# Altium schematic sheet 09 - MICROHEADER - JX3.SchDoc("09 - MICROHEADER - JX3")
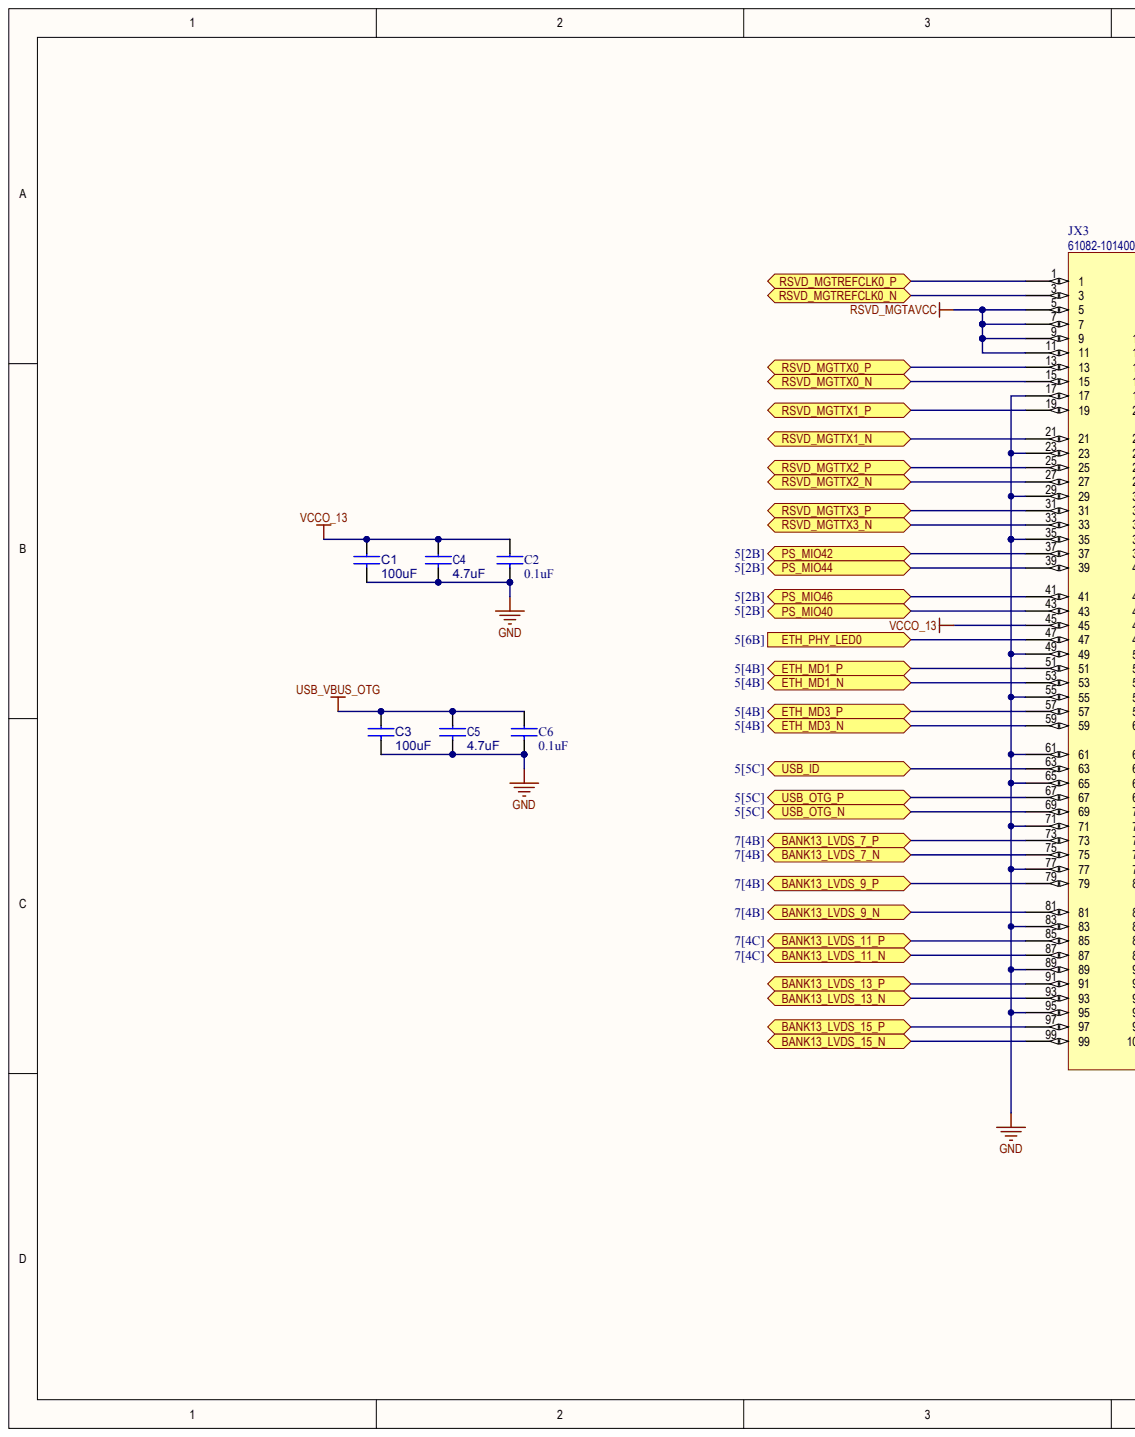
[diagram p0: 09 - MICROHEADER - JX3.SchDoc, left]
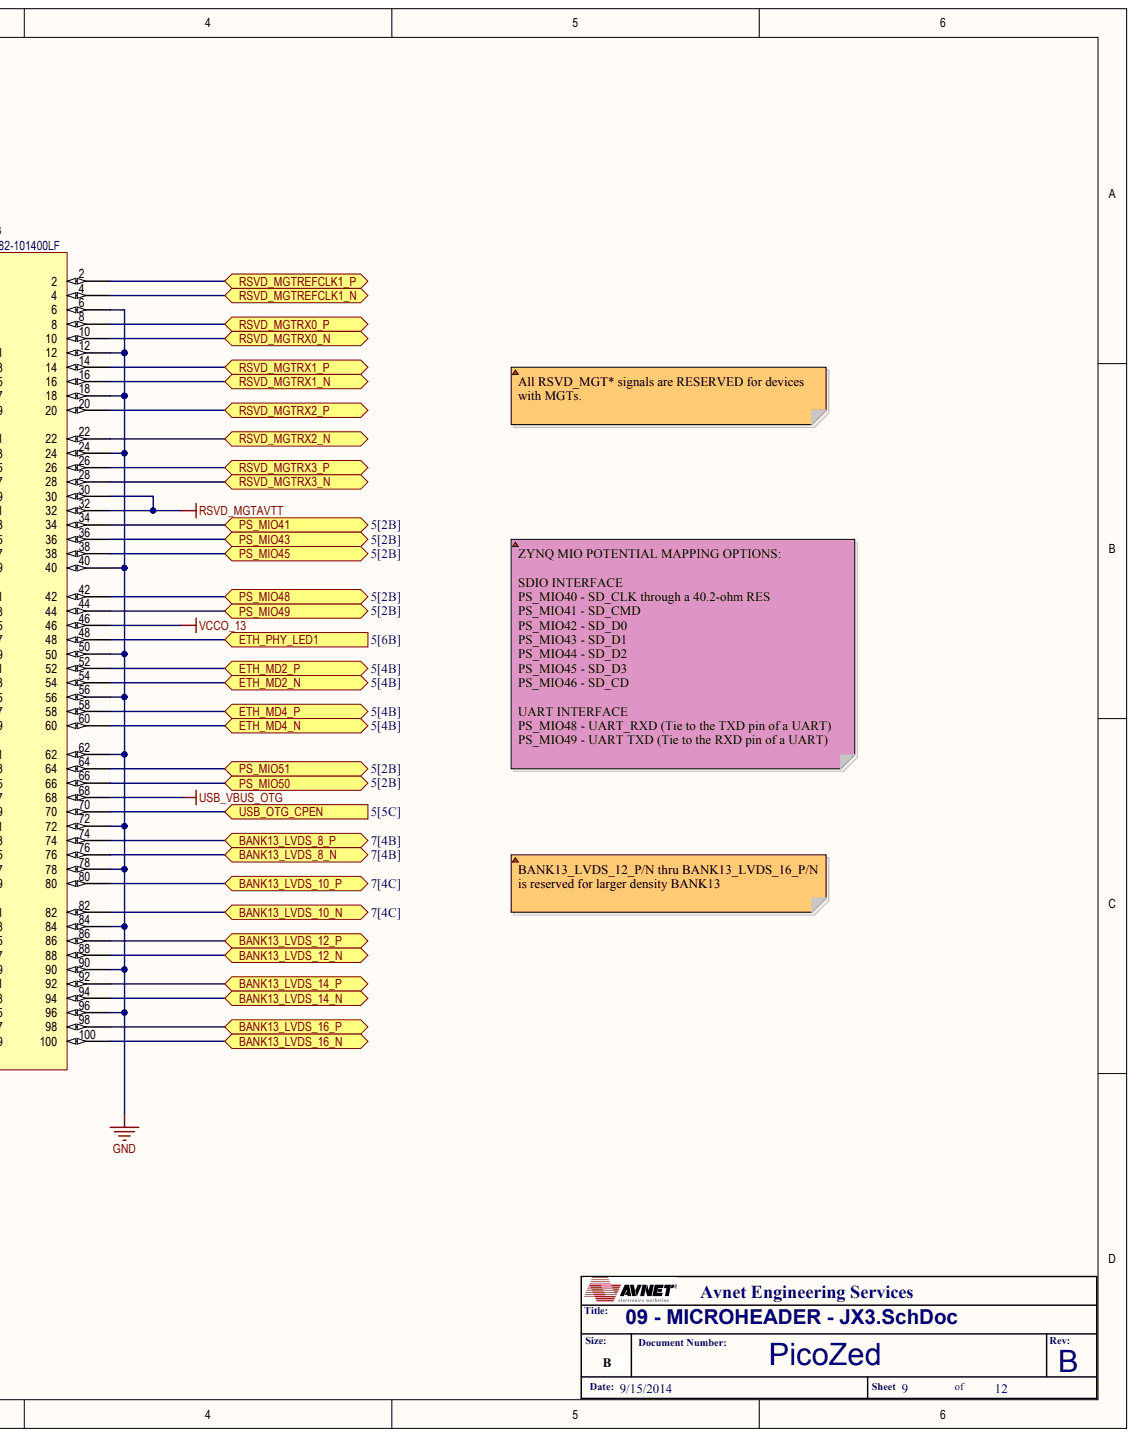
[diagram p1: 09 - MICROHEADER - JX3.SchDoc, right]

## Parts
C1  100uF
C2  value 0.1uF  (hidden comment: PC522)
C3  100uF
C4  4.7uF
C5  4.7uF
C6  value 0.1uF  (hidden comment: PC522)
JX3  61082-101400LF

## Ports
BANK13_LVDS_7_N, BANK13_LVDS_7_P, BANK13_LVDS_8_N, BANK13_LVDS_8_P, BANK13_LVDS_9_N, BANK13_LVDS_9_P, BANK13_LVDS_10_N, BANK13_LVDS_10_P, BANK13_LVDS_11_N, BANK13_LVDS_11_P, BANK13_LVDS_12_N, BANK13_LVDS_12_P, BANK13_LVDS_13_N, BANK13_LVDS_13_P, BANK13_LVDS_14_N, BANK13_LVDS_14_P, BANK13_LVDS_15_N, BANK13_LVDS_15_P, BANK13_LVDS_16_N, BANK13_LVDS_16_P, ETH_MD1_N, ETH_MD1_P, ETH_MD2_N, ETH_MD2_P, ETH_MD3_N, ETH_MD3_P, ETH_MD4_N, ETH_MD4_P, ETH_PHY_LED0, ETH_PHY_LED1, PS_MIO40, PS_MIO41, PS_MIO42, PS_MIO43, PS_MIO44, PS_MIO45, PS_MIO46, PS_MIO48, PS_MIO49, PS_MIO50, PS_MIO51, RSVD_MGTREFCLK0_N, RSVD_MGTREFCLK0_P, RSVD_MGTREFCLK1_N, RSVD_MGTREFCLK1_P, RSVD_MGTRX0_N, RSVD_MGTRX0_P, RSVD_MGTRX1_N, RSVD_MGTRX1_P, RSVD_MGTRX2_N, RSVD_MGTRX2_P, RSVD_MGTRX3_N, RSVD_MGTRX3_P, RSVD_MGTTX0_N, RSVD_MGTTX0_P, RSVD_MGTTX1_N, RSVD_MGTTX1_P, RSVD_MGTTX2_N, RSVD_MGTTX2_P, RSVD_MGTTX3_N, RSVD_MGTTX3_P, USB_ID, USB_OTG_CPEN, USB_OTG_N, USB_OTG_P

## Nets
GND: C1.2 C2.2 C3.2 C4.2 C5.2 C6.2 JX3.6 JX3.12 JX3.17 JX3.18 JX3.23 JX3.24 JX3.29 JX3.35 JX3.40 JX3.49 JX3.50 JX3.55 JX3.56 JX3.61 JX3.62 JX3.65 JX3.71 JX3.72 JX3.77 JX3.78 JX3.83 JX3.84 JX3.89 JX3.90 JX3.95 JX3.96
RSVD_MGTAVCC: JX3.5 JX3.7 JX3.9 JX3.11
RSVD_MGTAVTT: JX3.30 JX3.32
USB_VBUS_OTG: C3.1 C5.1 C6.1 JX3.68
VCCO_13: C1.1 C2.1 C4.1 JX3.45 JX3.46
BANK13_LVDS_7_N: JX3.75 ; port BANK13_LVDS_7_N
BANK13_LVDS_7_P: JX3.73 ; port BANK13_LVDS_7_P
BANK13_LVDS_8_N: JX3.76 ; port BANK13_LVDS_8_N
BANK13_LVDS_8_P: JX3.74 ; port BANK13_LVDS_8_P
BANK13_LVDS_9_N: JX3.81 ; port BANK13_LVDS_9_N
BANK13_LVDS_9_P: JX3.79 ; port BANK13_LVDS_9_P
BANK13_LVDS_10_N: JX3.82 ; port BANK13_LVDS_10_N
BANK13_LVDS_10_P: JX3.80 ; port BANK13_LVDS_10_P
BANK13_LVDS_11_N: JX3.87 ; port BANK13_LVDS_11_N
BANK13_LVDS_11_P: JX3.85 ; port BANK13_LVDS_11_P
BANK13_LVDS_12_N: JX3.88 ; port BANK13_LVDS_12_N
BANK13_LVDS_12_P: JX3.86 ; port BANK13_LVDS_12_P
BANK13_LVDS_13_N: JX3.93 ; port BANK13_LVDS_13_N
BANK13_LVDS_13_P: JX3.91 ; port BANK13_LVDS_13_P
BANK13_LVDS_14_N: JX3.94 ; port BANK13_LVDS_14_N
BANK13_LVDS_14_P: JX3.92 ; port BANK13_LVDS_14_P
BANK13_LVDS_15_N: JX3.99 ; port BANK13_LVDS_15_N
BANK13_LVDS_15_P: JX3.97 ; port BANK13_LVDS_15_P
BANK13_LVDS_16_N: JX3.100 ; port BANK13_LVDS_16_N
BANK13_LVDS_16_P: JX3.98 ; port BANK13_LVDS_16_P
ETH_MD1_N: JX3.53 ; port ETH_MD1_N
ETH_MD1_P: JX3.51 ; port ETH_MD1_P
ETH_MD2_N: JX3.54 ; port ETH_MD2_N
ETH_MD2_P: JX3.52 ; port ETH_MD2_P
ETH_MD3_N: JX3.59 ; port ETH_MD3_N
ETH_MD3_P: JX3.57 ; port ETH_MD3_P
ETH_MD4_N: JX3.60 ; port ETH_MD4_N
ETH_MD4_P: JX3.58 ; port ETH_MD4_P
ETH_PHY_LED0: JX3.47 ; port ETH_PHY_LED0
ETH_PHY_LED1: JX3.48 ; port ETH_PHY_LED1
PS_MIO40: JX3.43 ; port PS_MIO40
PS_MIO41: JX3.34 ; port PS_MIO41
PS_MIO42: JX3.37 ; port PS_MIO42
PS_MIO43: JX3.36 ; port PS_MIO43
PS_MIO44: JX3.39 ; port PS_MIO44
PS_MIO45: JX3.38 ; port PS_MIO45
PS_MIO46: JX3.41 ; port PS_MIO46
PS_MIO48: JX3.42 ; port PS_MIO48
PS_MIO49: JX3.44 ; port PS_MIO49
PS_MIO50: JX3.66 ; port PS_MIO50
PS_MIO51: JX3.64 ; port PS_MIO51
RSVD_MGTREFCLK0_N: JX3.3 ; port RSVD_MGTREFCLK0_N
RSVD_MGTREFCLK0_P: JX3.1 ; port RSVD_MGTREFCLK0_P
RSVD_MGTREFCLK1_N: JX3.4 ; port RSVD_MGTREFCLK1_N
RSVD_MGTREFCLK1_P: JX3.2 ; port RSVD_MGTREFCLK1_P
RSVD_MGTRX0_N: JX3.10 ; port RSVD_MGTRX0_N
RSVD_MGTRX0_P: JX3.8 ; port RSVD_MGTRX0_P
RSVD_MGTRX1_N: JX3.16 ; port RSVD_MGTRX1_N
RSVD_MGTRX1_P: JX3.14 ; port RSVD_MGTRX1_P
RSVD_MGTRX2_N: JX3.22 ; port RSVD_MGTRX2_N
RSVD_MGTRX2_P: JX3.20 ; port RSVD_MGTRX2_P
RSVD_MGTRX3_N: JX3.28 ; port RSVD_MGTRX3_N
RSVD_MGTRX3_P: JX3.26 ; port RSVD_MGTRX3_P
RSVD_MGTTX0_N: JX3.15 ; port RSVD_MGTTX0_N
RSVD_MGTTX0_P: JX3.13 ; port RSVD_MGTTX0_P
RSVD_MGTTX1_N: JX3.21 ; port RSVD_MGTTX1_N
RSVD_MGTTX1_P: JX3.19 ; port RSVD_MGTTX1_P
RSVD_MGTTX2_N: JX3.27 ; port RSVD_MGTTX2_N
RSVD_MGTTX2_P: JX3.25 ; port RSVD_MGTTX2_P
RSVD_MGTTX3_N: JX3.33 ; port RSVD_MGTTX3_N
RSVD_MGTTX3_P: JX3.31 ; port RSVD_MGTTX3_P
USB_ID: JX3.63 ; port USB_ID
USB_OTG_CPEN: JX3.70 ; port USB_OTG_CPEN
USB_OTG_N: JX3.69 ; port USB_OTG_N
USB_OTG_P: JX3.67 ; port USB_OTG_P

## Library data of the parts
C1 C3 = (no symbol name): PartCode: PC; AVLNo: AVPC-0000209; PkgType: SM; AsyTxt: PC209; Volt: 6.3V; Tol: 20%; Dielectric: X5R; MFG Code: MUR; MFGPN: GRM32EF50J107ZE20K; Power: 0; Device: CAP; Value: 100uF; RoHS: Yes; ComponentLink1Description: Datasheet; Availability: 180K-AV; Cost: .41; Size: 9.11; Status: Preliminary; Received: 0; CLASSNAME: DDR_TERM; ComponentLink1URL: C:\AVDBLIB\DATASHEETS\GRM31CR60J107ME39.pdf
C2 C6 = (no symbol name): PartCode: PC; AVLNo: AVPC-0000522; MFGPN: 02016D104KAT2A; PkgType: SM; Volt: 10V; Tol: 10%; Dielectric: X5R; Device: CAP; MFG Code: AVX; Status: Preliminary; AsyTxt: PC522; RoHS: Yes; ComponentLink1Description: Datasheet; CLASSNAME: U11_BYP; ComponentLink1URL: C:\AVDBLIB\DATASHEETS\AVX_CCOG.pdf
C4 C5 = (no symbol name): PartCode: PC; AVLNo: AVPC-0000499; PkgType: SM; AsyTxt: PC499; Volt: 6.3V; Tol: 20%; Dielectric: X5R; MFG Code: AVX; MFGPN: GRM155R60J475ME87D; Power: 0; Device: CAP; Value: 4.7uF; RoHS: Yes; ComponentLink1Description: Datasheet; Availability: 140K-DK; Cost: .46; Size: 1.9; Status: Preliminary; Received: 0; ComponentLink1URL: C:\AVDBLIB\DATASHEETS\murata_caps_c02e.pdf
JX3 = (no symbol name): MFG Code: FCI; AVLNo: AVEH-0000140; MFGPN: 61082-101400LF
